# Altium schematic sheet Sheet3.SchDoc(Sheet3)
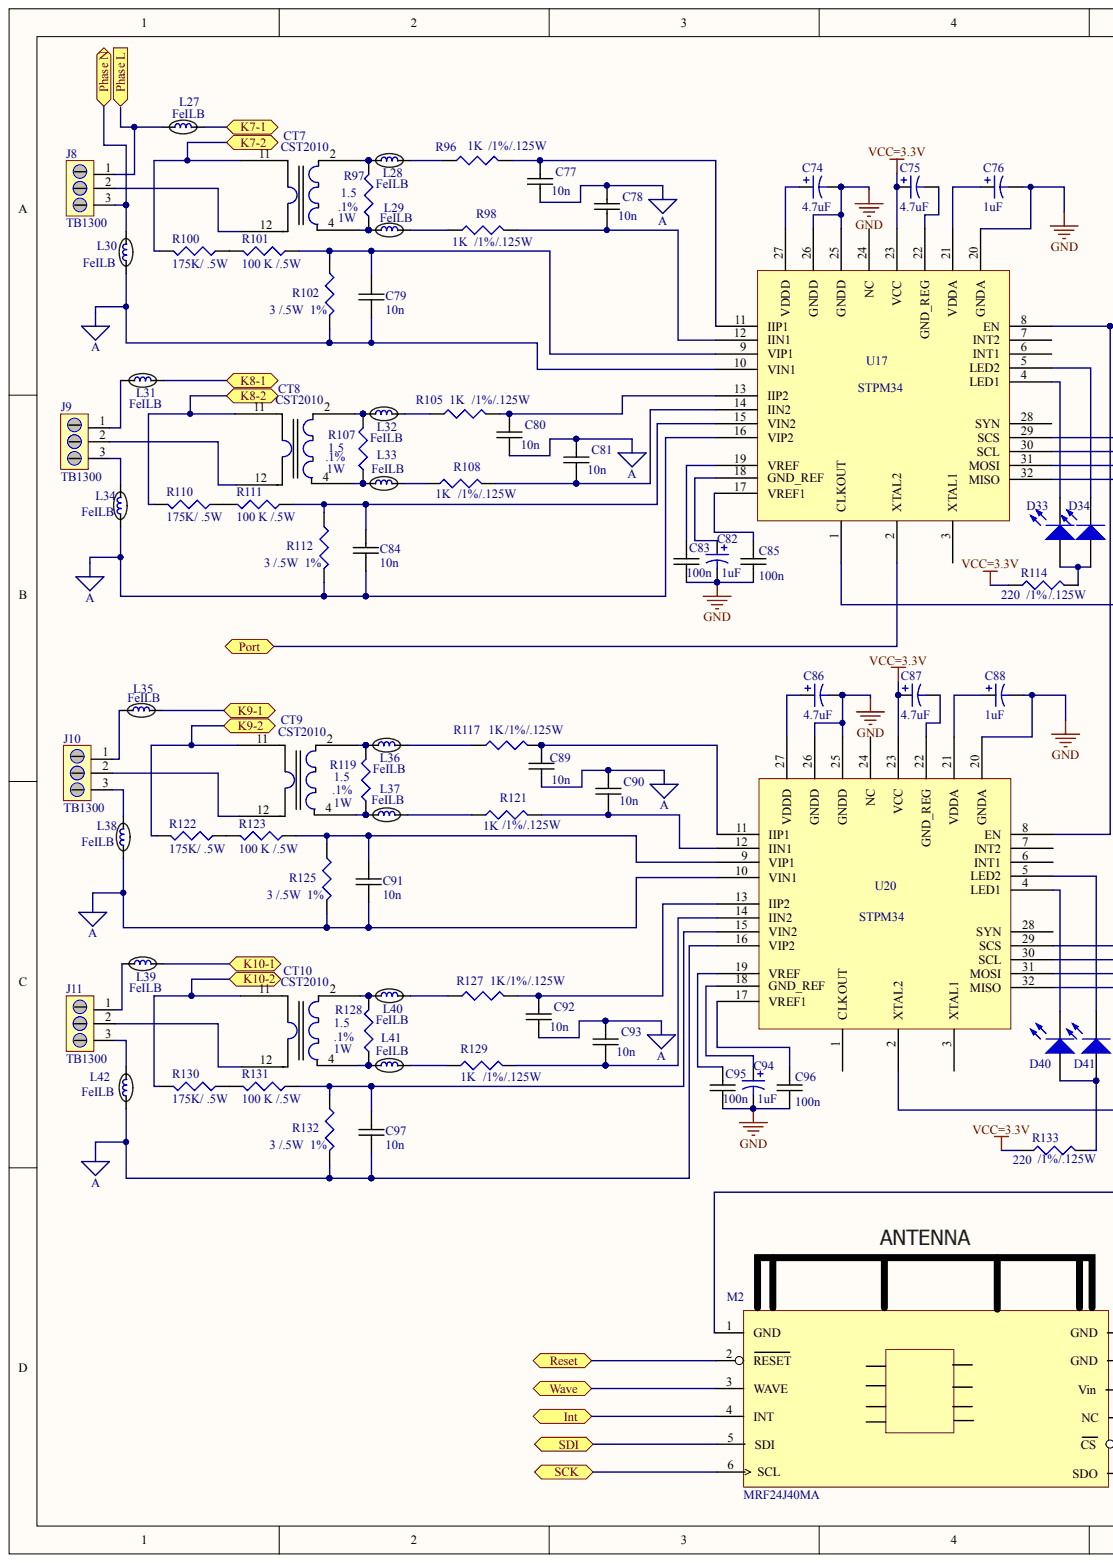
[diagram p0: Sheet3.SchDoc, left]
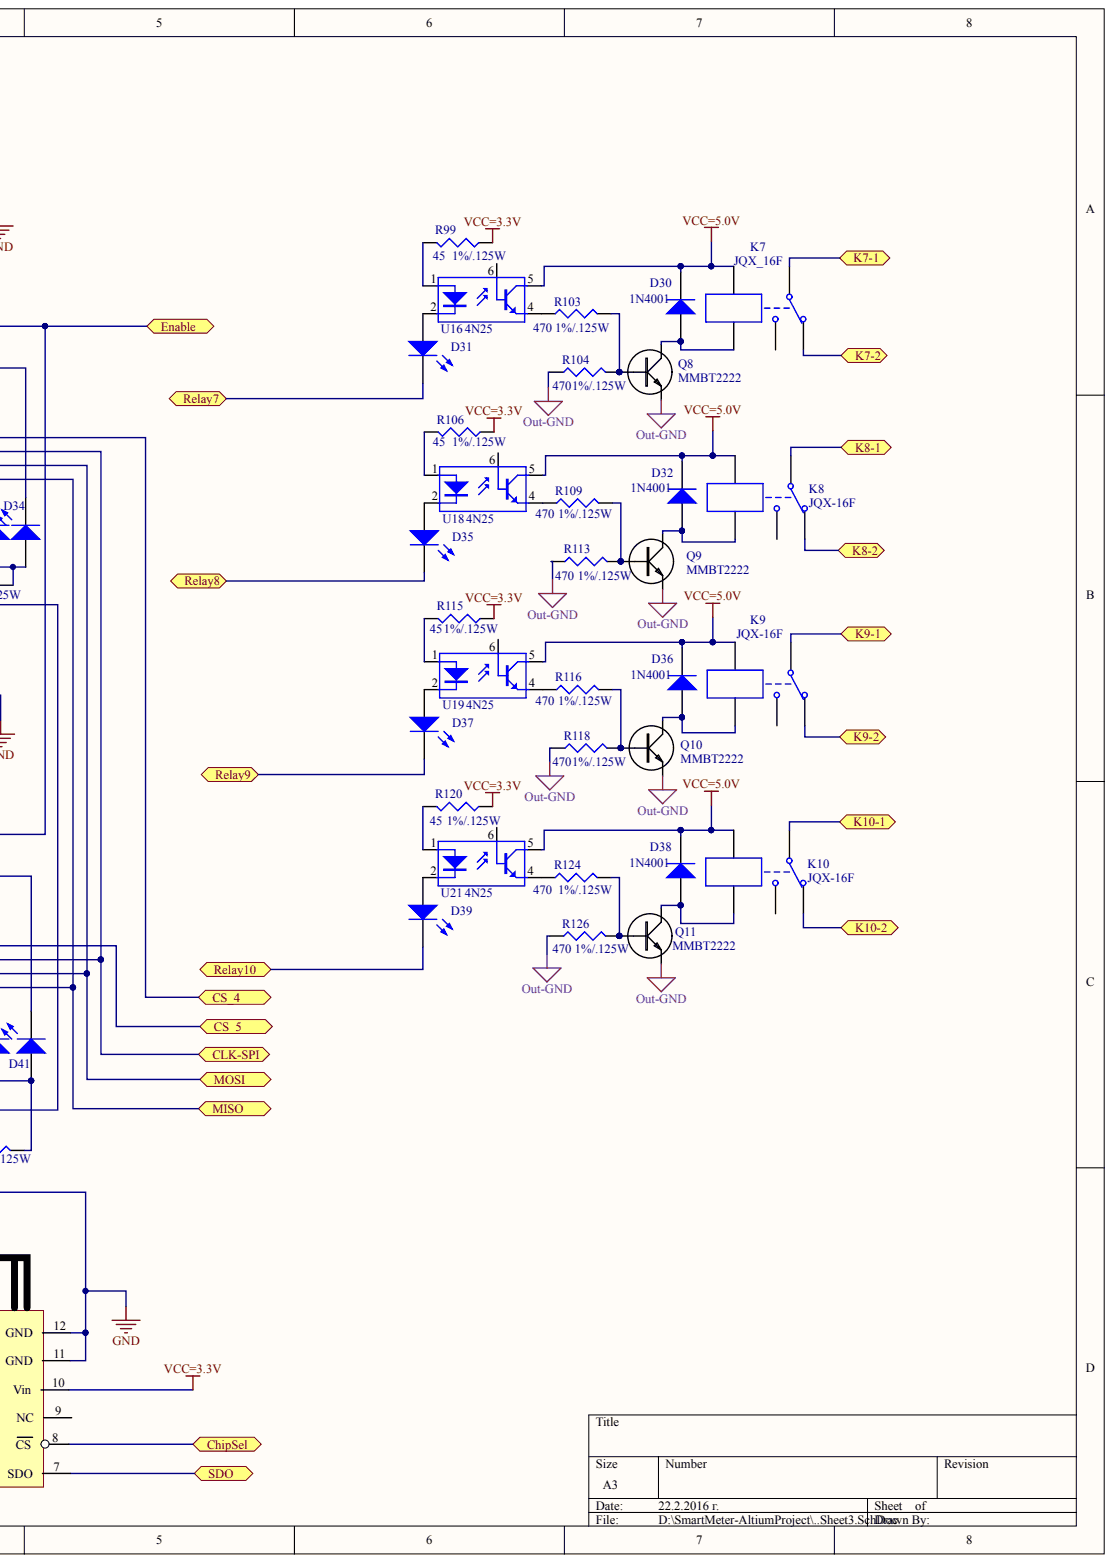
[diagram p1: Sheet3.SchDoc, right]

## Parts
C74  4.7uF
C75  4.7uF
C76  1uF
C77  10n
C78  10n
C79  10n
C80  10n
C81  10n
C82  1uF
C83  100n
C84  10n
C85  100n
C86  4.7uF
C87  4.7uF
C88  1uF
C89  10n
C90  10n
C91  10n
C92  10n
C93  10n
C94  1uF
C95  100n
C96  100n
C97  10n
CT7  CST2010
CT8  CST2010
CT9  CST2010
CT10  CST2010
D30  1N4001
D31  LED2  (value not drawn)
D32  1N4001
D33  LED2  (value not drawn)
D34  LED2  (value not drawn)
D35  LED2  (value not drawn)
D36  1N4001
D37  LED2  (value not drawn)
D38  1N4001
D39  LED2  (value not drawn)
D40  LED2  (value not drawn)
D41  LED2  (value not drawn)
J8  TB1300
J9  TB1300
J10  TB1300
J11  TB1300
K7  JQX_16F
K8  JQX-16F
K9  JQX-16F
K10  JQX-16F
L27  FeILB
L28  FeILB
L29  FeILB
L30  FeILB
L31  FeILB
L32  FeILB
L33  FeILB
L34  FeILB
L35  FeILB
L36  FeILB
L37  FeILB
L38  FeILB
L39  FeILB
L40  FeILB
L41  FeILB
L42  FeILB
M2  MRF24J40MA
Q8  MMBT2222
Q9  MMBT2222
Q10  MMBT2222
Q11  MMBT2222
R96  1K
R97  1.5
R98  1K
R99  45
R100  175K/ .5W
R101  100 K /.5W
R102  3 /.5W  1%
R103  470
R104  470
R105  1K
R106  45
R107  1.5
R108  1K
R109  470
R110  175K/ .5W
R111  100 K /.5W
R112  3 /.5W  1%
R113  470
R114  220
R115  45
R116  470
R117  1K
R118  470
R119  1.5
R120  45
R121  1K
R122  175K/ .5W
R123  100 K /.5W
R124  470
R125  3 /.5W  1%
R126  470
R127  1K
R128  1.5
R129  1K
R130  175K/ .5W
R131  100 K /.5W
R132  3 /.5W  1%
R133  220
U16  4N25
U17  STPM34
U18  4N25
U19  4N25
U20  STPM34
U21  4N25

## Ports
CHIPSEL, CLK-SPI, CS_4, CS_5, ENABLE, INT, K7-1, K7-2, K8-1, K8-2, K9-1, K9-2, K10-1, K10-2, MISO, MOSI, PHASE L, PHASE N, PORT, RELAY7, RELAY8, RELAY9, RELAY10, RESET, SCK, SDI, SDO, WAVE

## Nets
A: C77.1 C78.2 C79.1 C80.1 C81.2 C84.1 C89.1 C90.2 C91.1 C92.1 C93.2 C97.1 L30.1 L34.1 L38.1 L42.1 R102.2 R125.2 R132.2 U17.10(VIN1) U17.16(VIP2) U20.10(VIN1) U20.16(VIP2)
GND: C74.2 C76.2 C82.2 C83.1 C85.1 C86.2 C88.2 C94.2 C95.1 C96.1 M2.1(GND) M2.11(GND) M2.12(GND) U17.20(GNDA) U17.25(GNDD) U17.26(GNDD) U20.20(GNDA) U20.25(GNDD) U20.26(GNDD)
OUT-GND: Q8.E Q9.E Q10.E Q11.E R104.1 R113.1 R118.1 R126.1
VCC=3.3V: C75.1 C87.1 M2.10(Vin) R99.1 R106.1 R114.1 R115.1 R120.1 R133.1 U17.23(VCC) U20.23(VCC)
VCC=5.0V: D30.2 D32.2 D36.2 D38.2 K7.4 K8.4 K9.4 K10.4 U16.5 U18.5 U19.5 U21.5
CLK-SPI: U17.30(SCL) U20.30(SCL) ; port CLK-SPI
CS_4: U17.29(SCS) ; port CS_4
CS_5: U20.29(SCS) ; port CS_5
K7-1: K7.1 L27.2 ; port K7-1
K7-2: CT7.11 K7.2 R100.2 ; port K7-2
K8-1: K8.1 L31.2 ; port K8-1
K8-2: CT8.11 K8.2 R110.2 ; port K8-2
K9-1: K9.1 L35.2 ; port K9-1
K9-2: CT9.11 K9.2 R122.2 ; port K9-2
K10-1: K10.1 L39.2 ; port K10-1
K10-2: CT10.11 K10.2 R130.2 ; port K10-2
MISO: U17.32(MISO) U20.32(MISO) ; port MISO
MOSI: U17.31(MOSI) U20.31(MOSI) ; port MOSI
SCK: M2.6(SCL) ; port SCK
SDI: M2.5(SDI) ; port SDI
SDO: M2.7(SDO) ; port SDO
NetC74_1: C74.1 U17.27(VDDD)
NetC75_2: C75.2 U17.22(GND_REG)
NetC76_1: C76.1 U17.21(VDDA)
NetC77_2: C77.2 R96.2 U17.11(IIP1)
NetC78_1: C78.1 R98.2 U17.12(IIN1)
NetC79_2: C79.2 R101.1 R102.1 U17.9(VIP1)
NetC80_2: C80.2 R105.2 U17.13(IIP2)
NetC81_1: C81.1 R108.2 U17.14(IIN2)
NetC82_1: C82.1 U17.18(GND_REF)
NetC83_2: C83.2 U17.19(VREF)
NetC84_2: C84.2 R111.1 R112.1 U17.15(VIN2)
NetC85_2: C85.2 U17.17(VREF1)
NetC86_1: C86.1 U20.27(VDDD)
NetC87_2: C87.2 U20.22(GND_REG)
NetC88_1: C88.1 U20.21(VDDA)
NetC89_2: C89.2 R117.2 U20.11(IIP1)
NetC90_1: C90.1 R121.2 U20.12(IIN1)
NetC91_2: C91.2 R123.1 R125.1 U20.9(VIP1)
NetC92_2: C92.2 R127.2 U20.13(IIP2)
NetC93_1: C93.1 R129.2 U20.14(IIN2)
NetC94_1: C94.1 U20.18(GND_REF)
NetC95_2: C95.2 U20.19(VREF)
NetC96_2: C96.2 U20.17(VREF1)
NetC97_2: C97.2 R131.1 R132.1 U20.15(VIN2)
NetCT7_2: CT7.2 L28.1 R97.2
NetCT7_4: CT7.4 L29.1 R97.1
NetCT7_12: CT7.12 J8.2
NetCT8_2: CT8.2 L32.1 R107.2
NetCT8_4: CT8.4 L33.1 R107.1
NetCT8_12: CT8.12 J9.2
NetCT9_2: CT9.2 L36.1 R119.2
NetCT9_4: CT9.4 L37.1 R119.1
NetCT9_12: CT9.12 J10.2
NetCT10_2: CT10.2 L40.1 R128.2
NetCT10_4: CT10.4 L41.1 R128.1
NetCT10_12: CT10.12 J11.2
NetD30_1: D30.1 K7.5 Q8.C
NetD31_1: D31.1 U16.2
NetD31_2: D31.2 ; port RELAY7
NetD32_1: D32.1 K8.5 Q9.C
NetD33_1: D33.1 D34.1 R114.2
NetD33_2: D33.2 U17.4(LED1)
NetD34_2: D34.2 U17.5(LED2)
NetD35_1: D35.1 U18.2
NetD35_2: D35.2 ; port RELAY8
NetD36_1: D36.1 K9.5 Q10.C
NetD37_1: D37.1 U19.2
NetD37_2: D37.2 ; port RELAY9
NetD38_1: D38.1 K10.5 Q11.C
NetD39_1: D39.1 U21.2
NetD39_2: D39.2 ; port RELAY10
NetD40_1: D40.1 D41.1 R133.2
NetD40_2: D40.2 U20.4(LED1)
NetD41_2: D41.2 U20.5(LED2)
NetJ8_1: J8.1 L27.1
NetJ8_3: J8.3 L30.2 ; port PHASE N
NetJ9_1: J9.1 L31.1
NetJ9_3: J9.3 L34.2
NetJ10_1: J10.1 L35.1
NetJ10_3: J10.3 L38.2
NetJ11_1: J11.1 L39.1
NetJ11_3: J11.3 L42.2
NetL28_2: L28.2 R96.1
NetL29_2: L29.2 R98.1
NetL32_2: L32.2 R105.1
NetL33_2: L33.2 R108.1
NetL36_2: L36.2 R117.1
NetL37_2: L37.2 R121.1
NetL40_2: L40.2 R127.1
NetL41_2: L41.2 R129.1
NetM2_2: M2.2(RESET) ; port RESET
NetM2_3: M2.3(WAVE) ; port WAVE
NetM2_4: M2.4(INT) ; port INT
NetM2_8: M2.8(CS) ; port CHIPSEL
NetQ8_B: Q8.B R103.2 R104.2
NetQ9_B: Q9.B R109.2 R113.2
NetQ10_B: Q10.B R116.2 R118.2
NetQ11_B: Q11.B R124.2 R126.2
NetR99_2: R99.2 U16.1
NetR100_1: R100.1 R101.2
NetR103_1: R103.1 U16.4
NetR106_2: R106.2 U18.1
NetR109_1: R109.1 U18.4
NetR110_1: R110.1 R111.2
NetR115_2: R115.2 U19.1
NetR116_1: R116.1 U19.4
NetR120_2: R120.2 U21.1
NetR122_1: R122.1 R123.2
NetR124_1: R124.1 U21.4
NetR130_1: R130.1 R131.2
NetU17_1: U17.1(CLKOUT) U20.2(XTAL2)
NetU17_2: U17.2(XTAL2) ; port PORT
NetU17_8: U17.8(EN) U20.8(EN) ; port ENABLE
N00441: ; port PHASE L

Not connected: K7 3; K8 3; K9 3; K10 3; M2 9(NC); R112 2; U16 6; U17 3(XTAL1) 6(INT1) 7(INT2) 24(NC) 28(SYN); U18 6; U19 6; U20 1(CLKOUT) 3(XTAL1) 6(INT1) 7(INT2) 24(NC) 28(SYN); U21 6

## Library data of the parts
C74-C76 C82 C86-C88 C94 = (no symbol name): Value: 100pF; Published: 8-Jun-2000; LatestRevisionDate: 29-May-2009; LatestRevisionNote: IPC-7351 Footprint Added.; PackageReference: C0805; PackageDescription: Chip Capacitor; Publisher: Altium Limited
C83 C85 C95 C96 = (no symbol name): Value: 10n; Supplier 1: Digi-Key; Supplier Part Number 1: 709-1171-2-ND; mfr PN: *; Package reference: 0805-TBD
R96-R98 R105 R107 R108 R114 R117 R119 R121 R127-R129 R133 = (no symbol name): Value: 1K 1%; Published: 8-Jun-2000; LatestRevisionDate: 29-May-2009; LatestRevisionNote: IPC-7351 Footprint Added.; PackageReference: J1-0603; PackageDescription: Chip Resistor; Publisher: Altium Limited
  R98 R108 R114 R121 R129 R133: manufacture P/N: *
R99 R106 R115 R120 = (no symbol name): Value: 45/.125/1%; Published: 8-Jun-2000; LatestRevisionDate: 29-May-2009; LatestRevisionNote: IPC-7351 Footprint Added.; PackageReference: J1-0603; PackageDescription: Chip Resistor; mfr PN: *; Publisher: Altium Limited
R100 R110 R122 R130 = (no symbol name): Value: 175k/ .5 W 1%; Published: 8-Jun-2000; LatestRevisionDate: 29-May-2009; LatestRevisionNote: IPC-7351 Footprint Added.; PackageReference: J1-0603; PackageDescription: Chip Resistor; mfr PN: *; Package Reference: 1206; Publisher: Altium Limited
R101 R111 R123 R131 = (no symbol name): Value: 100k /.5 W 1%; Published: 8-Jun-2000; LatestRevisionDate: 29-May-2009; LatestRevisionNote: IPC-7351 Footprint Added.; PackageReference: J1-0603; PackageDescription: Chip Resistor; mfr PN: *; Package Reference: 1206; Publisher: Altium Limited
R102 R112 R125 R132 = (no symbol name): Value: 3 .125W 1%; Published: 8-Jun-2000; LatestRevisionDate: 29-May-2009; LatestRevisionNote: IPC-7351 Footprint Added.; PackageReference: J1-0603; PackageDescription: Chip Resistor; Publisher: Altium Limited
R103 R104 R109 R113 R116 R118 R124 R126 = (no symbol name): Value: 470/.125W/1%; Published: 8-Jun-2000; LatestRevisionDate: 29-May-2009; LatestRevisionNote: IPC-7351 Footprint Added.; PackageReference: J1-0603; PackageDescription: Chip Resistor; Publisher: Altium Limited
C77-C81 C84 C89-C93 C97 CT7-CT10 D30-D41 J8-J11 K7-K10 L27-L42 M2 Q8-Q11 U16-U21 = (no symbol name)
  C77 C78 C80 C81 C89 C90 C92 C93: Supplier 1: Digi-Key; Supplier Part Number 1: 709-1171-2-ND; Value: 10n; mfr PN: *; Package reference: 0805-TBD
  C79 C84 C91 C97: Supplier 1: Digi-Key; Supplier Part Number 1: 709-1171-2-ND; Value: 10n; mfr PN: *; Package Reference: 0805-TBD
  D30 D32 D36 D38: Published: 8-Jun-2000; LatestRevisionDate: 17-Jul-2002; LatestRevisionNote: Re-released for DXP Platform.; DatasheetVersion: 1998; PackageVersion: Aug-1999; PackageReference: DO-41; PackageDescription: Diode, Axial; Body 5.2 x 2.7 mm (LxDia. max), Lead Dia. 0.86 mm (max); Code_JEDEC: DO-204-AL; ComponentLink1Description: Manufacturer Link; ComponentLink1URL: http://www.fairchildsemi.com/; ComponentLink2Description: Datasheet; ComponentLink2URL: http://www.fairchildsemi.com/ds/1N%2F1N4001.pdf
  D31 D33-D35 D37 D39-D41: Published: 8-Jun-2000; LatestRevisionDate: 17-Jul-2002; LatestRevisionNote: Re-released for DXP Platform.; PackageVersion: Feb-2002; PackageReference: 3.2X1.6X1.1; PackageDescription: SM LED; 2 Flat Leads
  K7-K10: Published: 24-Mar-1999; LatestRevisionDate: 17-Jul-2002; LatestRevisionNote: Re-released for DXP Platform.; PackageReference: MODULE5B
  Q8-Q11: Supplier 1: Digi-Key; Supplier Part Number 1: MMBT2222A-FDICT-ND
  U16 U18 U19 U21: Published: 24-Mar-1999; LatestRevisionDate: 04-Mar-2005; LatestRevisionNote: PCB Footprint replaced, Sch pins re-numbered.; DatasheetVersion: Apr-2004; PackageReference: SOP5(6); PackageDescription: Small Outline; 6 Leads (1 Absent); Body Width 4.4 mm; Pitch 1.27 mm
  D30-D41 K7-K10 U16 U18 U19 U21: Publisher: Altium Limited
